# Altium schematic sheet 1_Power.SchDoc
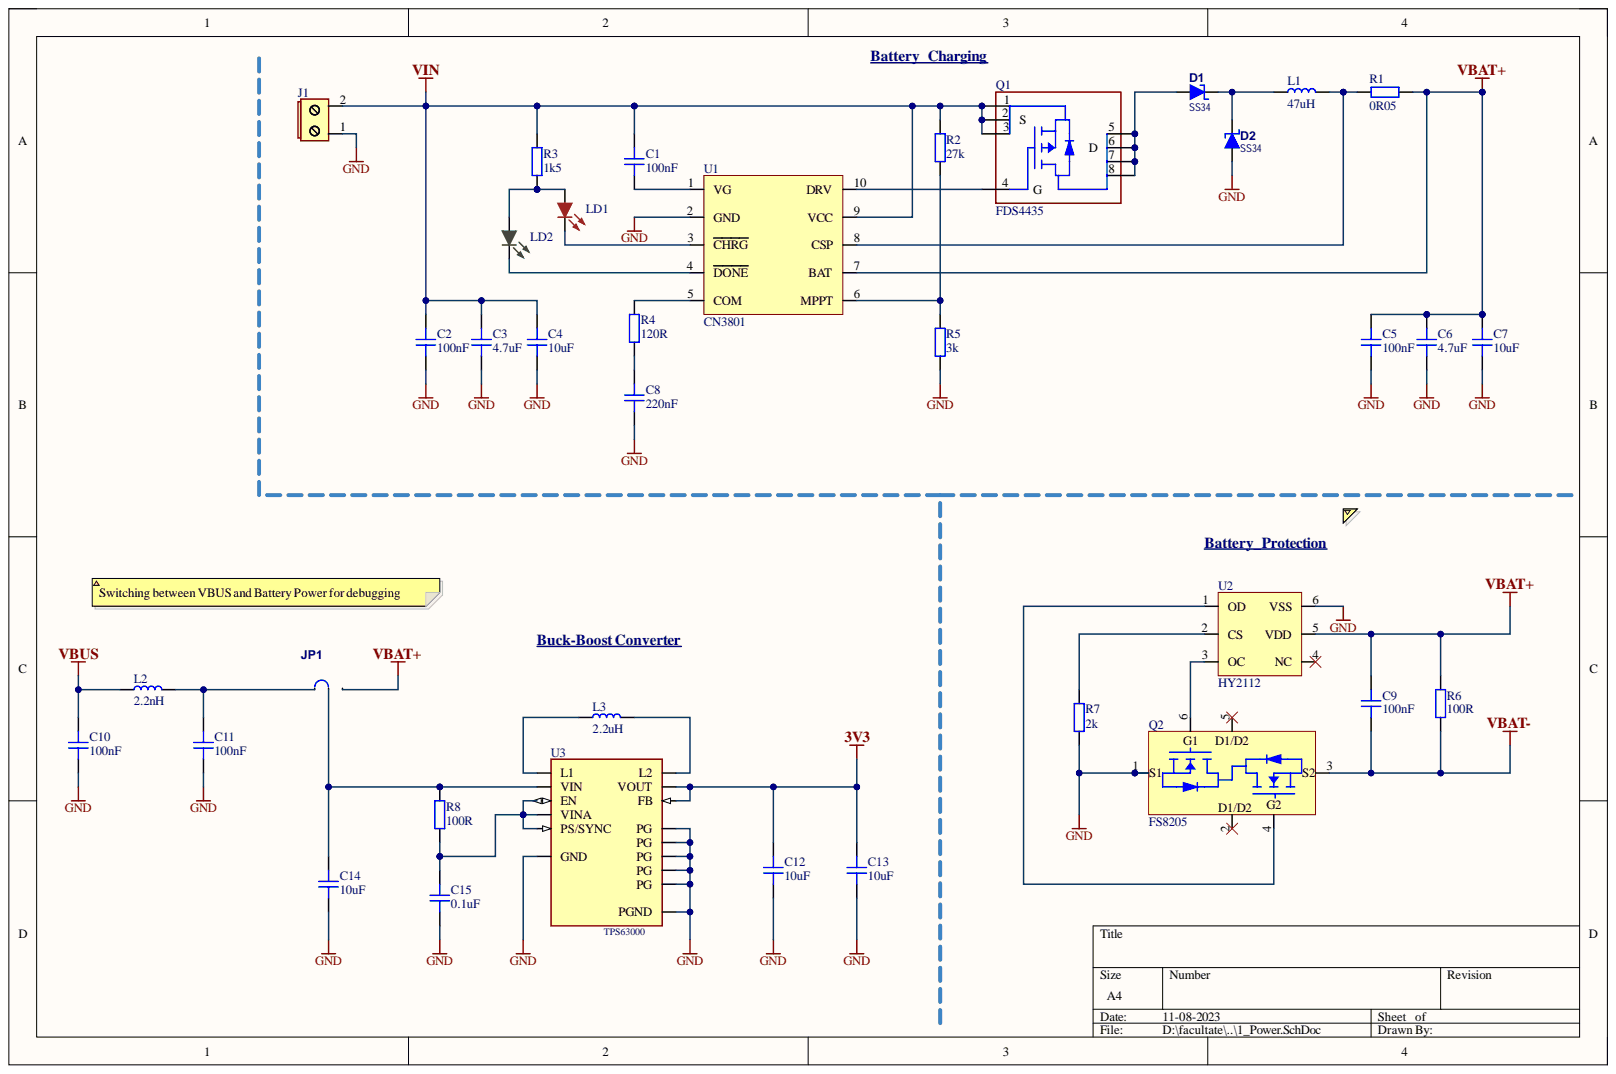
[diagram p0: 1_Power.SchDoc]

## Parts
C1  100nF  [CAP_0603]
C2  100nF  [CAP_0603]
C3  4.7uF  [CAP_0603]
C4  10uF  [CAP_0805]
C5  100nF  [CAP_0603]
C6  4.7uF  [CAP_0603]
C7  10uF  [CAP_0805]
C8  220nF  [CAP_0603]
C9  100nF  [CAP_0603]
C10  100nF  [CAP_0603]
C11  100nF  [CAP_0603]
C12  10uF  [CAP_0805]
C13  10uF  [CAP_0805]
C14  10uF  [CAP_0805]
C15  0.1uF  [CAP_0603]
D1  SS34  [DO-213AA]
D2  SS34  [DO-213AA]
J1  PLUGIN_TERMINAL  (value not drawn)
JP1  JUMPER_3PIN  [JUMPER_SMD_3]  (value not drawn)
L1  47uH  [IND_0603]
L2  2.2nH  [IND_1008]
L3  2.2uH  [IND_1008]
LD1  LED_RED  [3.5X2.8X1.9]  (value not drawn)
LD2  LED_GREEN  [3.5X2.8X1.9]  (value not drawn)
Q1  FDS4435
Q2  FS8205
R1  0R05  [RES_0805]
R2  27k  [RES_0603]
R3  1k5  [RES_0603]
R4  120R  [RES_0603]
R5  3k  [RES_0603]
R6  100R  [RES_0603]
R7  2k  [RES_0603]
R8  100R  [RES_0603]
U1  CN3801
U2  HY2112
U3  MP: TPS63000  [10-VFDFN]  (hidden comment: TPS63000_1)

## Nets
3V3: C12.2 C13.2 U3.1(VOUT) U3.10(FB)
GND: C2.1 C3.1 C4.1 C5.1 C6.1 C7.1 C8.1 C10.1 C11.1 C12.1 C13.1 C14.1 C15.1 D2.1 J1.1 Q2.1(S1) R5.1 R7.1 U1.2(GND) U2.6(VSS) U3.3(PGND) U3.9(GND) U3.P$1(PG) U3.P$2(PG) U3.P$3(PG) U3.P$4(PG) U3.P$5(PG)
VBAT+: C5.2 C6.2 C7.2 C9.2 JP1.3 R1.2 R6.2 U1.7(BAT) U2.5(VDD)
VBAT-: C9.1 Q2.3(S2) R6.1
VBUS: C10.2 L2.1
VIN: C1.2 C2.2 C3.2 C4.2 J1.2 Q1.1 Q1.2(S) Q1.3 R2.2 R3.2 U1.9(VCC)
NetC1_1: C1.1 U1.1(VG)
NetC8_2: C8.2 R4.1
NetC11_2: C11.2 JP1.1 L2.2
NetC14_2: C14.2 JP1.2 R8.2 U3.5(VIN)
NetC15_2: C15.2 R8.1 U3.6(EN) U3.7(PS/SYNC) U3.8(VINA)
NetD1_1: D1.1 Q1.5 Q1.6(D) Q1.7 Q1.8
NetD1_2: D1.2 D2.2 L1.1
NetL1_2: L1.2 R1.1 U1.8(CSP)
NetL3_1: L3.1 U3.4(L1)
NetL3_2: L3.2 U3.2(L2)
NetLD1_1: LD1.1 LD2.1 R3.1
NetLD1_2: LD1.2 U1.3(CHRG)
NetLD2_2: LD2.2 U1.4(DONE)
NetQ1_4: Q1.4(G) U1.10(DRV)
NetQ2_4: Q2.4(G2) U2.1(OD)
NetQ2_6: Q2.6(G1) U2.3(OC)
NetR2_1: R2.1 R5.2 U1.6(MPPT)
NetR4_2: R4.2 U1.5(COM)
NetR7_2: R7.2 U2.2(CS)

Not connected: Q2 2 5; U2 4(NC)

## Library data of the parts
C1-C3 C5 C6 C8-C11 C15 = CAP_0603: Capacitor SMD 0603; Component Kind: Standard; Library Name: Schlib1.SchLib
C4 C7 C12-C14 = CAP_0805: Capacitor SMD 0805; Component Kind: Standard; Library Name: Schlib1.SchLib
D1 D2 = DIODE_SCHOTTKY: Diode; Component Kind: Standard; Library Name: Schlib1.SchLib
J1 = Power_Connector: Screw terminal or plug terminal for power; Component Kind: Standard; Library Name: Schlib1.SchLib
L1 = IND_0603: Inductor SMD 0603; Component Kind: Standard; Library Name: Schlib1.SchLib
L2 L3 = IND_1008: Inductor SMD 1008; Component Kind: Standard; Library Name: Schlib1.SchLib
LD1 = LED_RED: Typical BLUE SiC LED; Component Kind: Standard; DatasheetVersion: 16-Apr-2002; LatestRevisionDate: 17-Dec-2003; LatestRevisionNote: PCB Footprint replaced.; Library Name: Schlib1.SchLib; PackageDescription: SM LED; 2 C-Bend Leads; Body 3.5 x 2.8 x 1.9 mm, inc leads (LxWxH typ); PackageReference: 3.5X2.8X1.9; PackageVersion: 16-Apr-2002; Published: 8-Jun-2000; Signal Integrity: LED; Simulation: LED3; Publisher: Altium Limited
LD2 = LED_GREEN: Typical BLUE SiC LED; Component Kind: Standard; DatasheetVersion: 16-Apr-2002; LatestRevisionDate: 17-Dec-2003; LatestRevisionNote: PCB Footprint replaced.; Library Name: Schlib1.SchLib; PackageDescription: SM LED; 2 C-Bend Leads; Body 3.5 x 2.8 x 1.9 mm, inc leads (LxWxH typ); PackageReference: 3.5X2.8X1.9; PackageVersion: 16-Apr-2002; Published: 8-Jun-2000; Signal Integrity: LED; Simulation: LED3; Publisher: Altium Limited
R1 = RES_0805: Resistor SMD 0805; Component Kind: Standard; Library Name: Schlib1.SchLib
R2-R6 R8 = RES_0603: Resistor SMD 0603; Component Kind: Standard; Library Name: Schlib1.SchLib
R7 = RES_0603_1: Resistor SMD 0603; Component Kind: Standard; Library Name: Schlib1.SchLib
U1 = CN3801: LiFePO4 charge IC with integrated MPPT and wide input range (4.5 to 28V) with deeply discharged cell revive; Component Kind: Standard; Library Name: Schlib1.SchLib
U2 = HY2112: LiFePO4 protection IC; Component Kind: Standard; Library Name: Schlib1.SchLib
U3 = TPS63000_1: Buck-Boost Switching Regulator IC Positive Adjustable 1.2V 1 Output 1.6A _Switch_ 10-VFDFN Exposed Pad; MF: Texas Instruments; Availability: Unavailable; Component Kind: Standard; Library Name: Schlib1.SchLib; Package: QFN-10 Texas Instruments; Price: None; SnapEDA_Link: https://www.snapeda.com/parts/TPS63000/Texas%20Instruments/view-part/572521/?ref=snap
JP1 = JUMPER_3PIN: Component Kind: Standard; Library Name: Schlib1.SchLib
Q1 = FDS4435: Component Kind: Standard; Library Name: Schlib1.SchLib
Q2 = FS8205: Component Kind: Standard; Library Name: Schlib1.SchLib
